# Altium schematic sheet MDBT50Q_2.SchDoc(MDBT50Q_2)
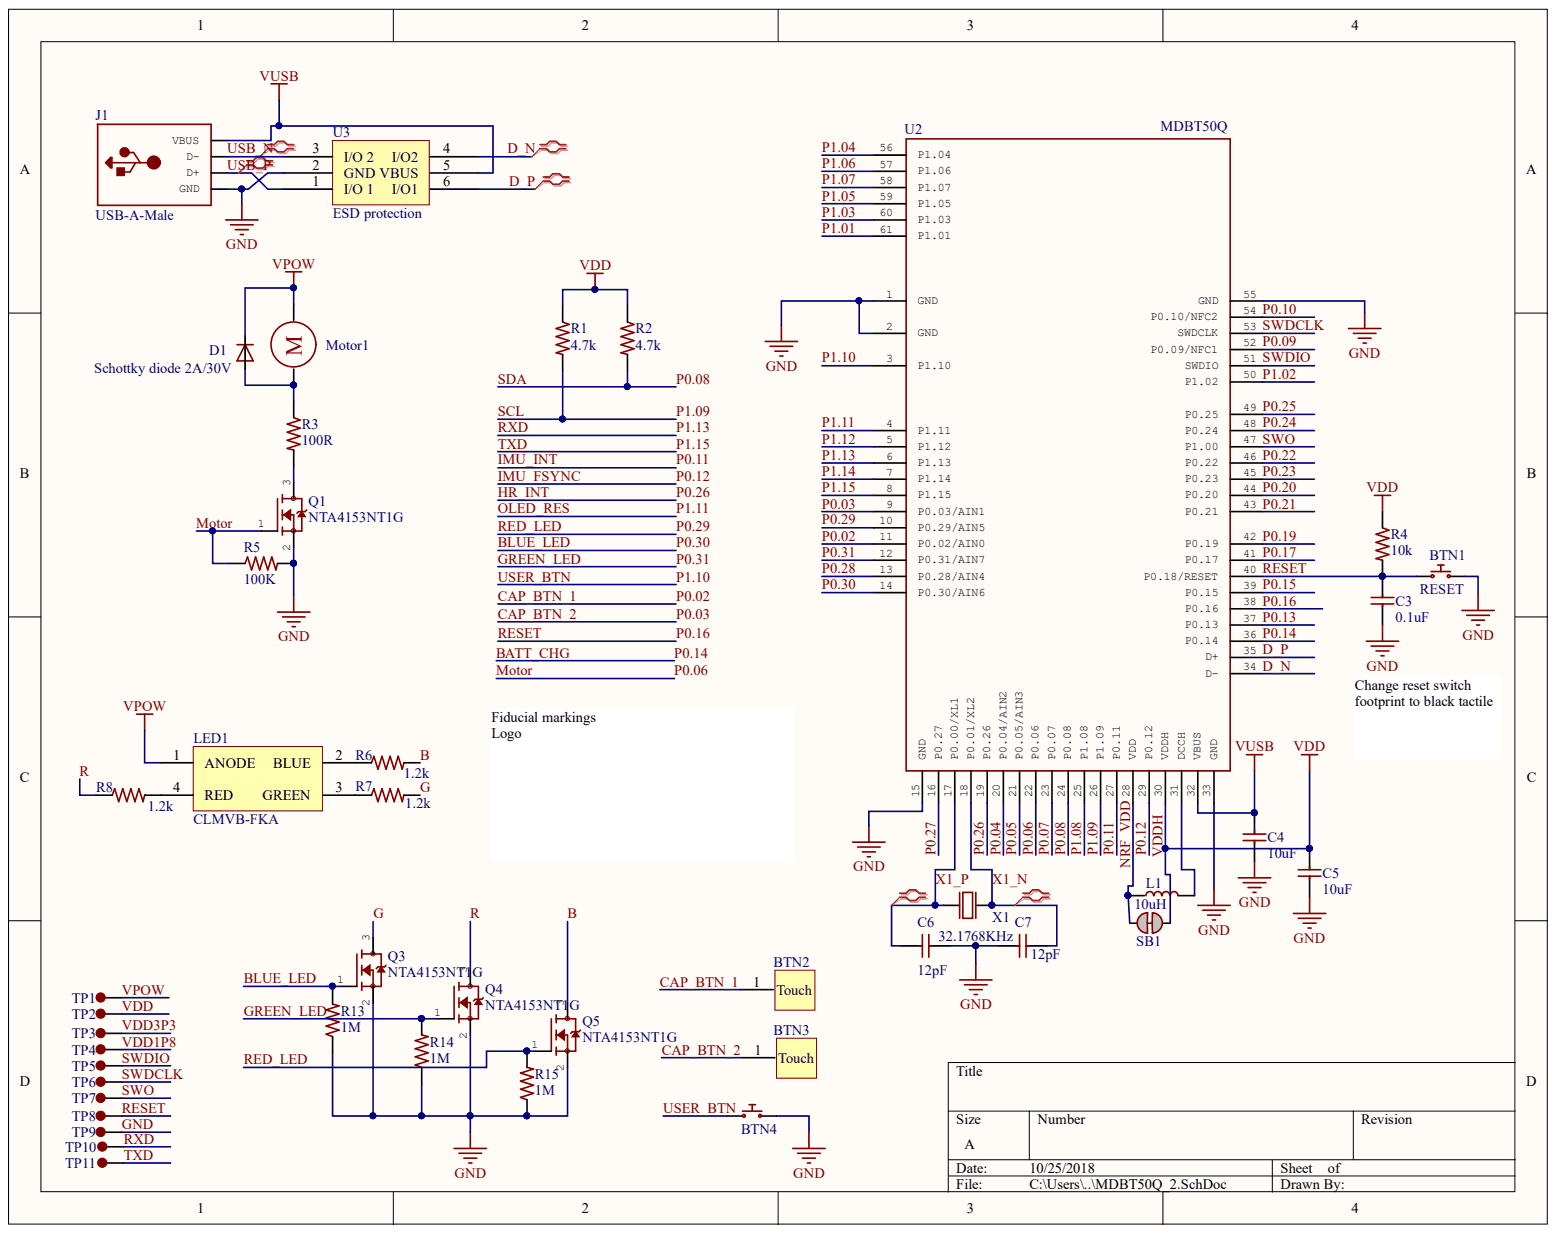
[diagram p0: MDBT50Q_2.SchDoc]

## Parts
BTN1  RESET  [SKSWCFE010]
BTN2  Capacitive button  [rectange touch pad]  (value not drawn)
BTN3  Capacitive button  [rectange touch pad]  (value not drawn)
BTN4  Switch  [KAN1542-0291B0201]  (value not drawn)
C3  0.1uF  [CAP0603]
C4  10uF  [CAP0603]
C5  10uF  [CAP0603]
C6  12pF  [CAP0603]
C7  12pF  [CAP0603]
D1  Schottky diode 2A/30V  [SOD-123FL]
J1  USB-A-Male  [PCB-USB-A]
L1  10uH  [IND0604]
LED1  CLMVB-FKA  [CLMVB-FKA]
Motor1  Vibration Motor (Black)  [Black vibration motor SMD]  (value not drawn)
Q1  NTA4153NT1G  [SC-75]
Q3  NTA4153NT1G  [SC-75]
Q4  NTA4153NT1G  [SC-75]
Q5  NTA4153NT1G  [SC-75]
R1  4.7k  [RES0603]
R2  4.7k  [RES0603]
R3  100R  [RES0603]
R4  10k  [RES0603]
R5  100K  [RES0603]
R6  1.2k  [RES0603]
R7  1.2k  [RES0603]
R8  1.2k  [RES0603]
R13  1M  [RES0603]
R14  1M  [RES0603]
R15  1M  [RES0603]
SB1  Shorted Solder Bridge  [Shorted Solder Bridge]  (value not drawn)
TP1  1mm TP  [TP 1mm]  (value not drawn)
TP2  1mm TP  [TP 1mm]  (value not drawn)
TP3  1mm TP  [TP 1mm]  (value not drawn)
TP4  1mm TP  [TP 1mm]  (value not drawn)
TP5  1mm TP  [TP 1mm]  (value not drawn)
TP6  1mm TP  [TP 1mm]  (value not drawn)
TP7  1mm TP  [TP 1mm]  (value not drawn)
TP8  1mm TP  [TP 1mm]  (value not drawn)
TP9  1mm TP  [TP 1mm]  (value not drawn)
TP10  1mm TP  [TP 1mm]  (value not drawn)
TP11  1mm TP  [TP 1mm]  (value not drawn)
U2  MDBT50Q  [MDBT50Q]
U3  ESD protection  [SOT-666]
X1  32.1768KHz  [DFN240P320X90-2N]

## Nets
VUSB: C4.2 J1.1(VBUS) U2.32(VBUS) U3.5(VBUS)
B: Q5.3 R6.2
BATT_CHG: U2.36(P0.14)
BLUE_LED: Q3.1 R13.2 U2.14(P0.30/AIN6)
CAP_BTN_1: BTN2.1 U2.11(P0.02/AIN0)
CAP_BTN_2: BTN3.1 U2.9(P0.03/AIN1)
D_N: U2.34(D-) U3.4(I/O2)
D_P: U2.35(D+) U3.6(I/O1)
G: Q3.3 R7.2
GND: BTN1.1 BTN4.2 C3.1 C4.1 C5.1 C6.2 C7.1 J1.4(GND) Q1.2 Q3.2 Q4.2 Q5.2 R5.2 R13.1 R14.1 R15.1 TP9.1 U2.1(GND) U2.2(GND) U2.15(GND) U2.33(GND) U2.55(GND) U3.2(GND)
GREEN_LED: Q4.1 R14.2 U2.12(P0.31/AIN7)
HR_INT: U2.19(P0.26)
IMU_FSYNC: U2.29(P0.12)
IMU_INT: U2.27(P0.11)
MOTOR: Q1.1 R5.1 U2.22(P0.06)
NRF_VDD: L1.1 SB1.1 U2.28(VDD)
OLED_RES: U2.4(P1.11)
P0.04: U2.20(P0.04/AIN2)
P0.05: U2.21(P0.05/AIN3)
P0.07: U2.23(P0.07)
P0.08: R2.2 U2.24(P0.08)
P0.09: U2.52(P0.09/NFC1)
P0.10: U2.54(P0.10/NFC2)
P0.13: U2.37(P0.13)
P0.15: U2.39(P0.15)
P0.16: BTN1.2 C3.2 R4.2 TP8.1 U2.38(P0.16) U2.40(P0.18/RESET)
P0.17: U2.41(P0.17)
P0.19: U2.42(P0.19)
P0.20: U2.44(P0.20)
P0.21: U2.43(P0.21)
P0.22: U2.46(P0.22)
P0.23: U2.45(P0.23)
P0.24: U2.48(P0.24)
P0.25: U2.49(P0.25)
P0.27: U2.16(P0.27)
P0.28: U2.13(P0.28/AIN4)
P0.29: Q5.1 R15.2 U2.10(P0.29/AIN5)
P1.01: U2.61(P1.01)
P1.02: U2.50(P1.02)
P1.03: U2.60(P1.03)
P1.04: U2.56(P1.04)
P1.05: U2.59(P1.05)
P1.06: U2.57(P1.06)
P1.07: U2.58(P1.07)
P1.08: U2.25(P1.08)
P1.09: R1.2 U2.26(P1.09)
P1.10: BTN4.1 U2.3(P1.10)
P1.12: U2.5(P1.12)
P1.13: TP10.1 U2.6(P1.13)
P1.14: U2.7(P1.14)
P1.15: TP11.1 U2.8(P1.15)
R: Q4.3 R8.1
SWDCLK: TP6.1 U2.53(SWDCLK)
SWDIO: TP5.1 U2.51(SWDIO)
SWO: TP7.1 U2.47(P1.00)
USB_N: J1.2(D-) U3.3(I/O_2)
USB_P: J1.3(D+) U3.1(I/O_1)
VDD: C5.2 R1.1 R2.1 R4.1 SB1.2 TP2.1 U2.30(VDDH)
VDD1P8: TP4.1
VDD3P3: TP3.1
VPOW: D1.C LED1.1(ANODE) Motor1.2 TP1.1
X1_N: C7.2 U2.18(P0.01/XL2) X1.2
X1_P: C6.1 U2.17(P0.00/XL1) X1.1
NetD1_A: D1.A Motor1.1 R3.1
NetL1_2: L1.2 U2.31(DCCH)
NetLED1_2: LED1.2(BLUE) R6.1
NetLED1_3: LED1.3(GREEN) R7.1
NetLED1_4: LED1.4(RED) R8.2
NetQ1_3: Q1.3 R3.2

## Library data of the parts
BTN1 BTN4 = Switch: Tactile Switch; Component Kind: Standard; Library Name: karo_components.IntLib
C3-C7 = Capacitor: Component Kind: Standard; Library Name: karo_components.IntLib
D1 = DIODE_SOD-123FL: Component Kind: Standard; Library Name: adafruit.IntLib
L1 = Inductor: Component Kind: Standard; Description: Inductor; Library Name: karo_components.IntLib
LED1 = CLMVB-FKA: RGB LED; Component Kind: Standard; Library Name: karo_components.IntLib
Motor1 = Motor: SMD Vibration motor black; Component Kind: Standard; Library Name: karo_components.IntLib
Q1 Q3-Q5 = NTA4153NT1G: N Channel MOSFET; Component Kind: Standard; Library Name: karo_components.IntLib
R1-R8 R13-R15 = Resistor: Component Kind: Standard; Library Name: karo_components.IntLib
TP1-TP11 = 1mm TP: TP of 1mm diameter; Component Kind: Standard; Library Name: karo_components.IntLib
U2 = MDBT50Q: NRF52840 module; Component Kind: Standard; Library Name: karo_components.IntLib
U3 = USBLC6-2P6: ESD protection for USB; Component Kind: Standard; Library Name: karo_components.IntLib
X1 = RTC SMD Crystal: RTC 32.1768KHz crystal; Component Kind: Standard; Library Name: karo_components.IntLib
BTN2 BTN3 = Capacitive button: Component Kind: Standard; Description: capacitive button; Library Name: karo_components.IntLib
J1 = USB-A-Male: Component Kind: Standard; Library Name: karo_components.IntLib
SB1 = Shorted Solder Bridge: Component Kind: Standard; Library Name: karo_components.IntLib
